# Altium schematic sheet Module.SchDoc
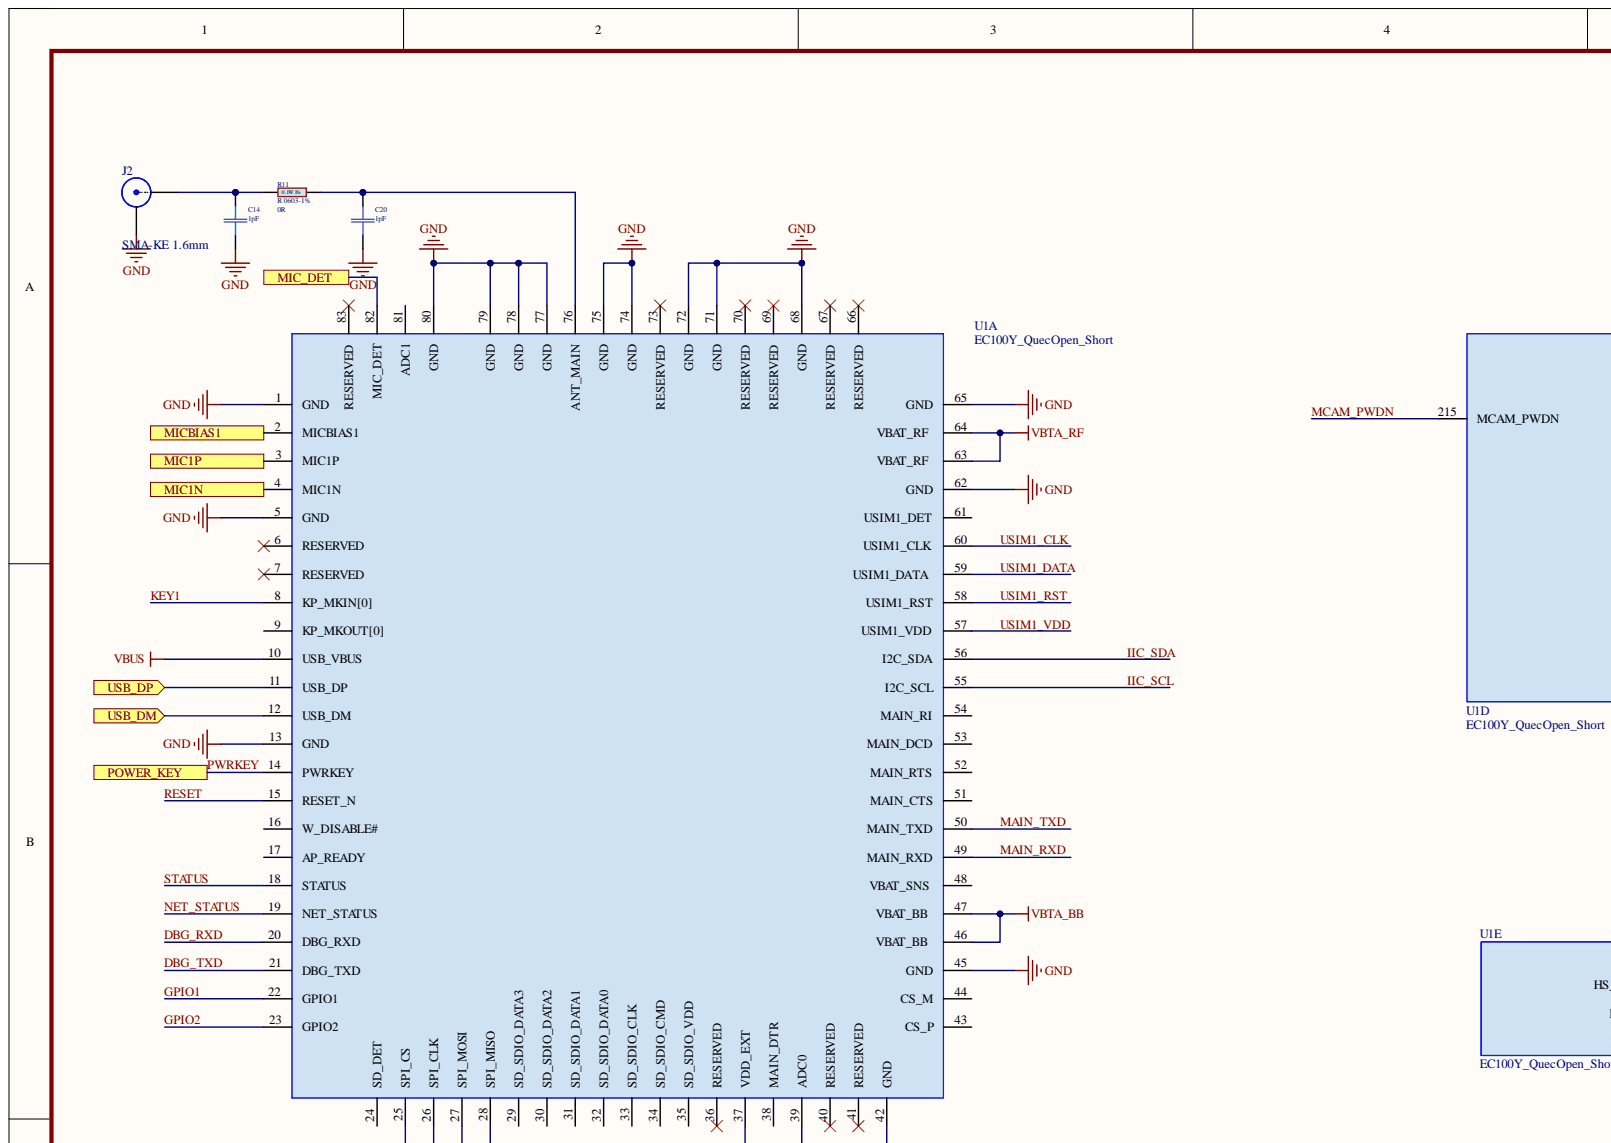
[diagram p0: Module.SchDoc, top left]
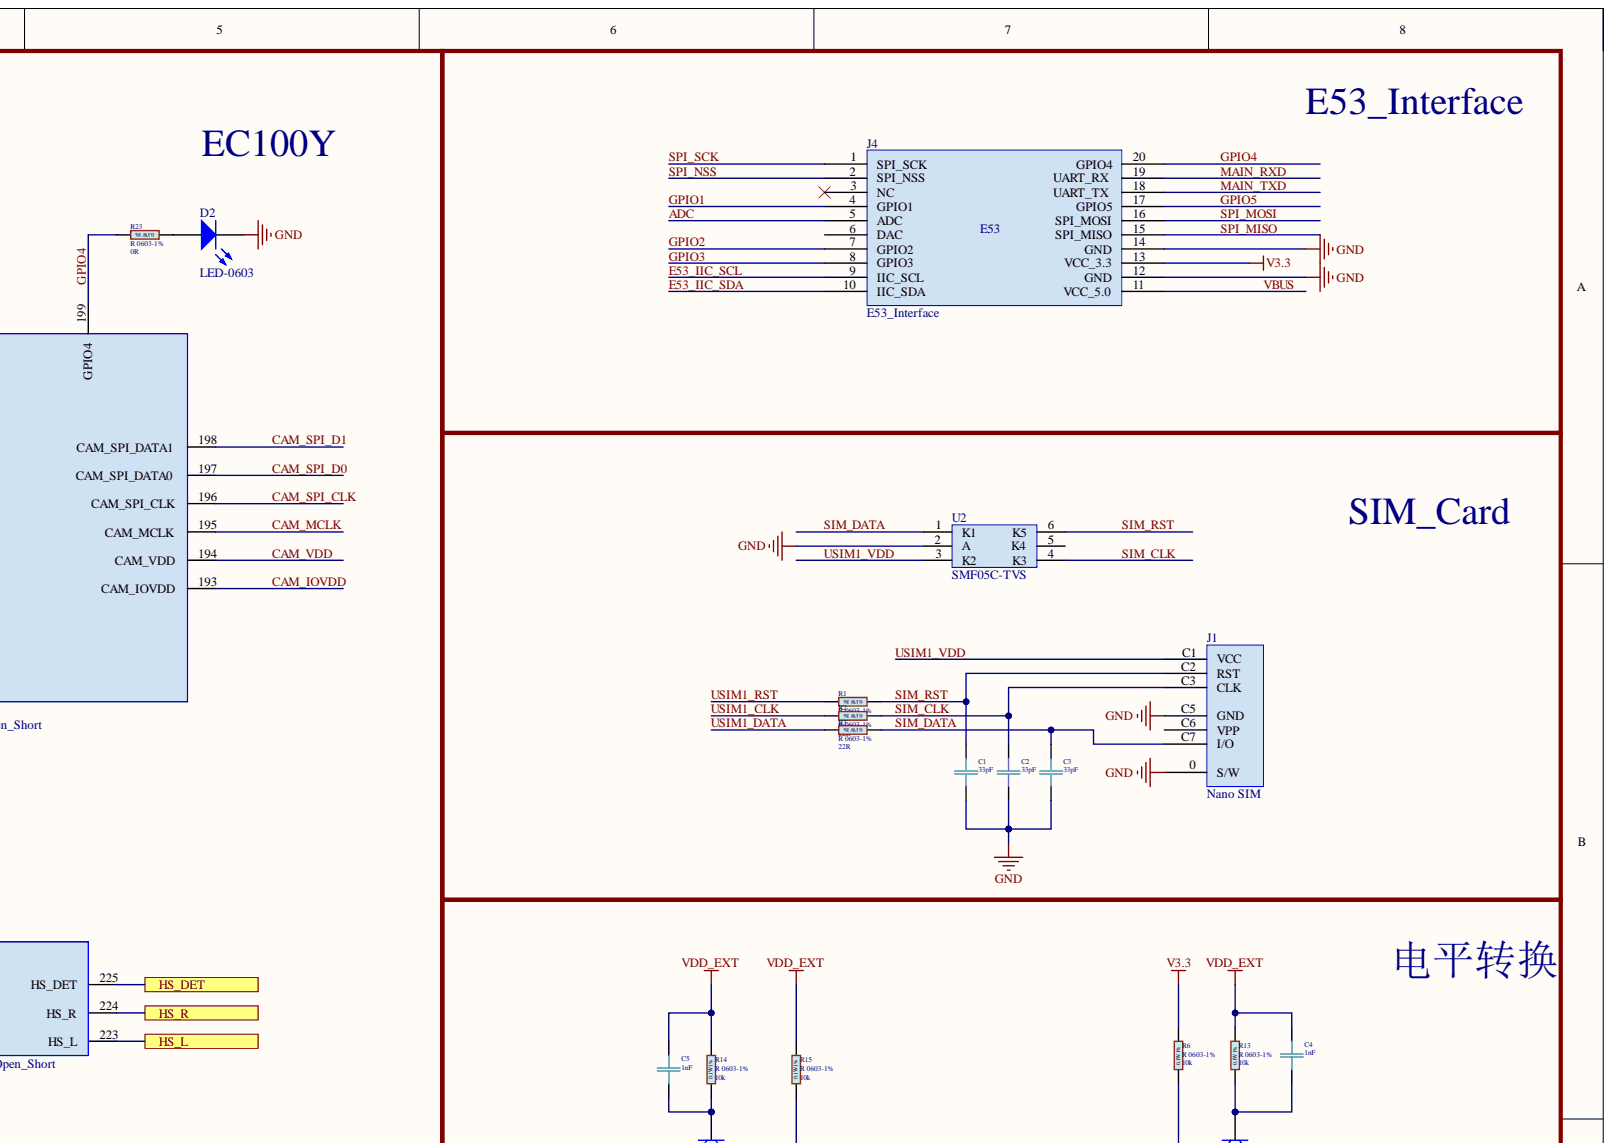
[diagram p1: Module.SchDoc, top right]
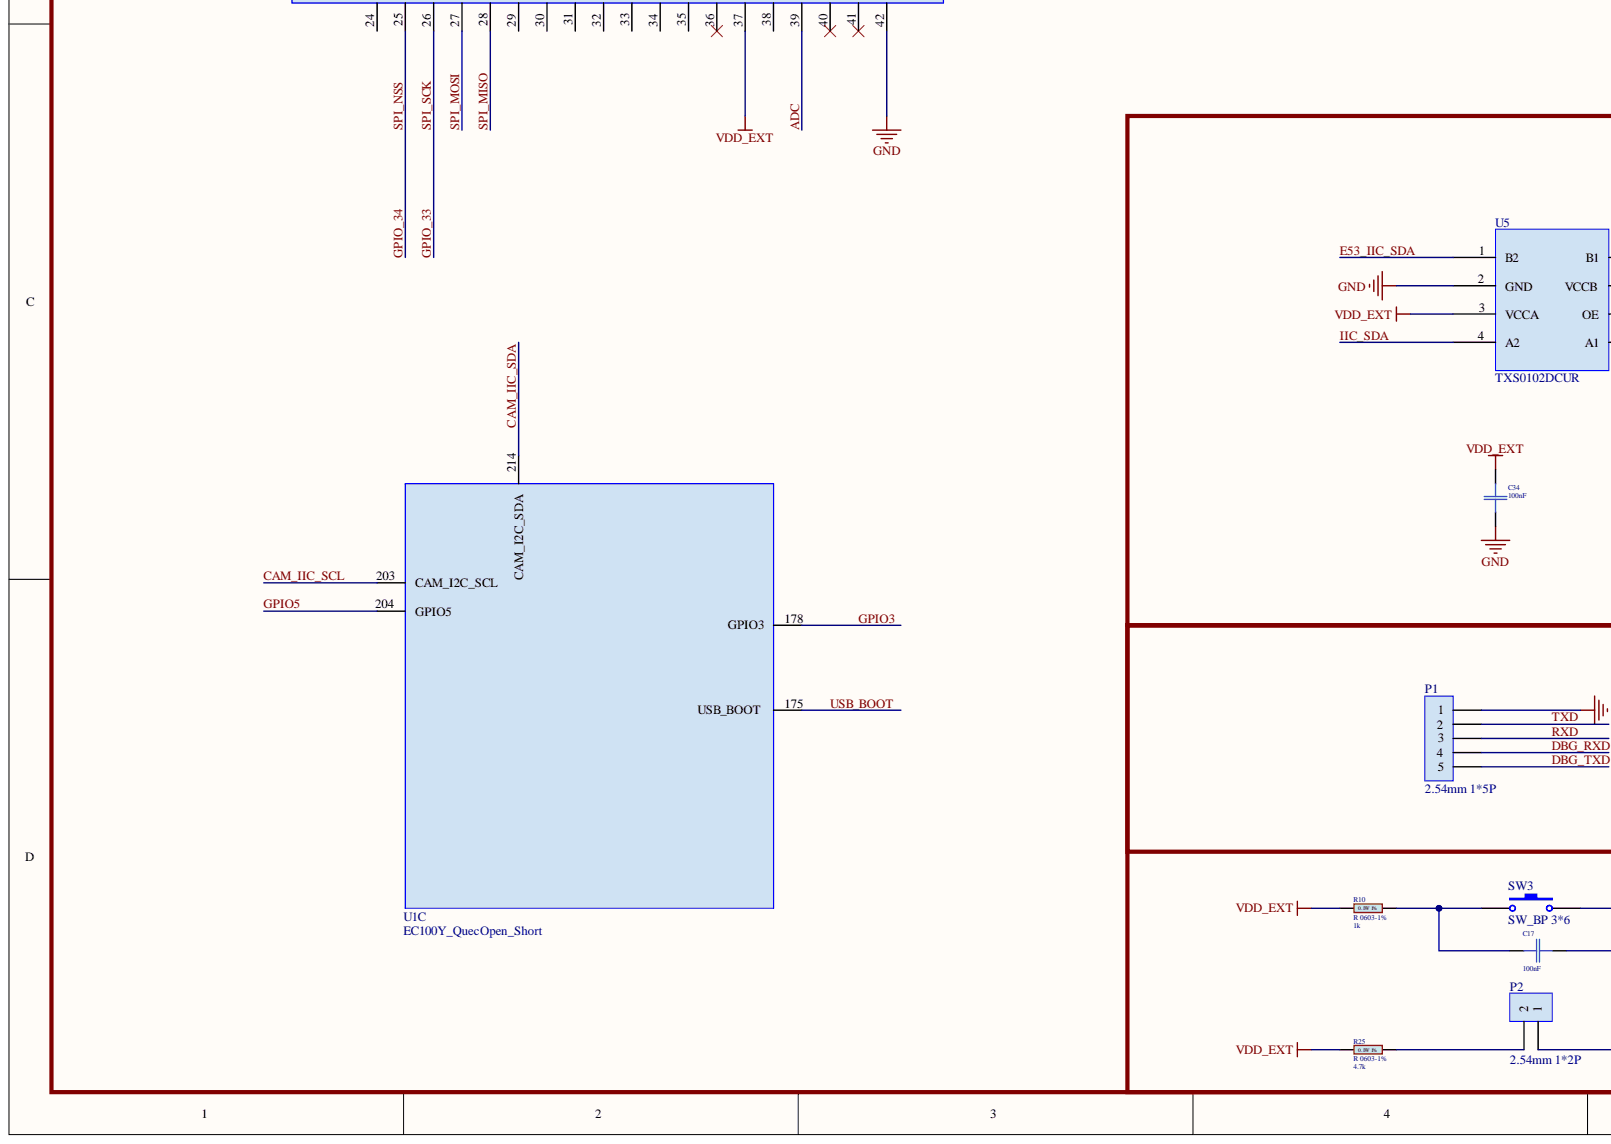
[diagram p2: Module.SchDoc, bottom left]
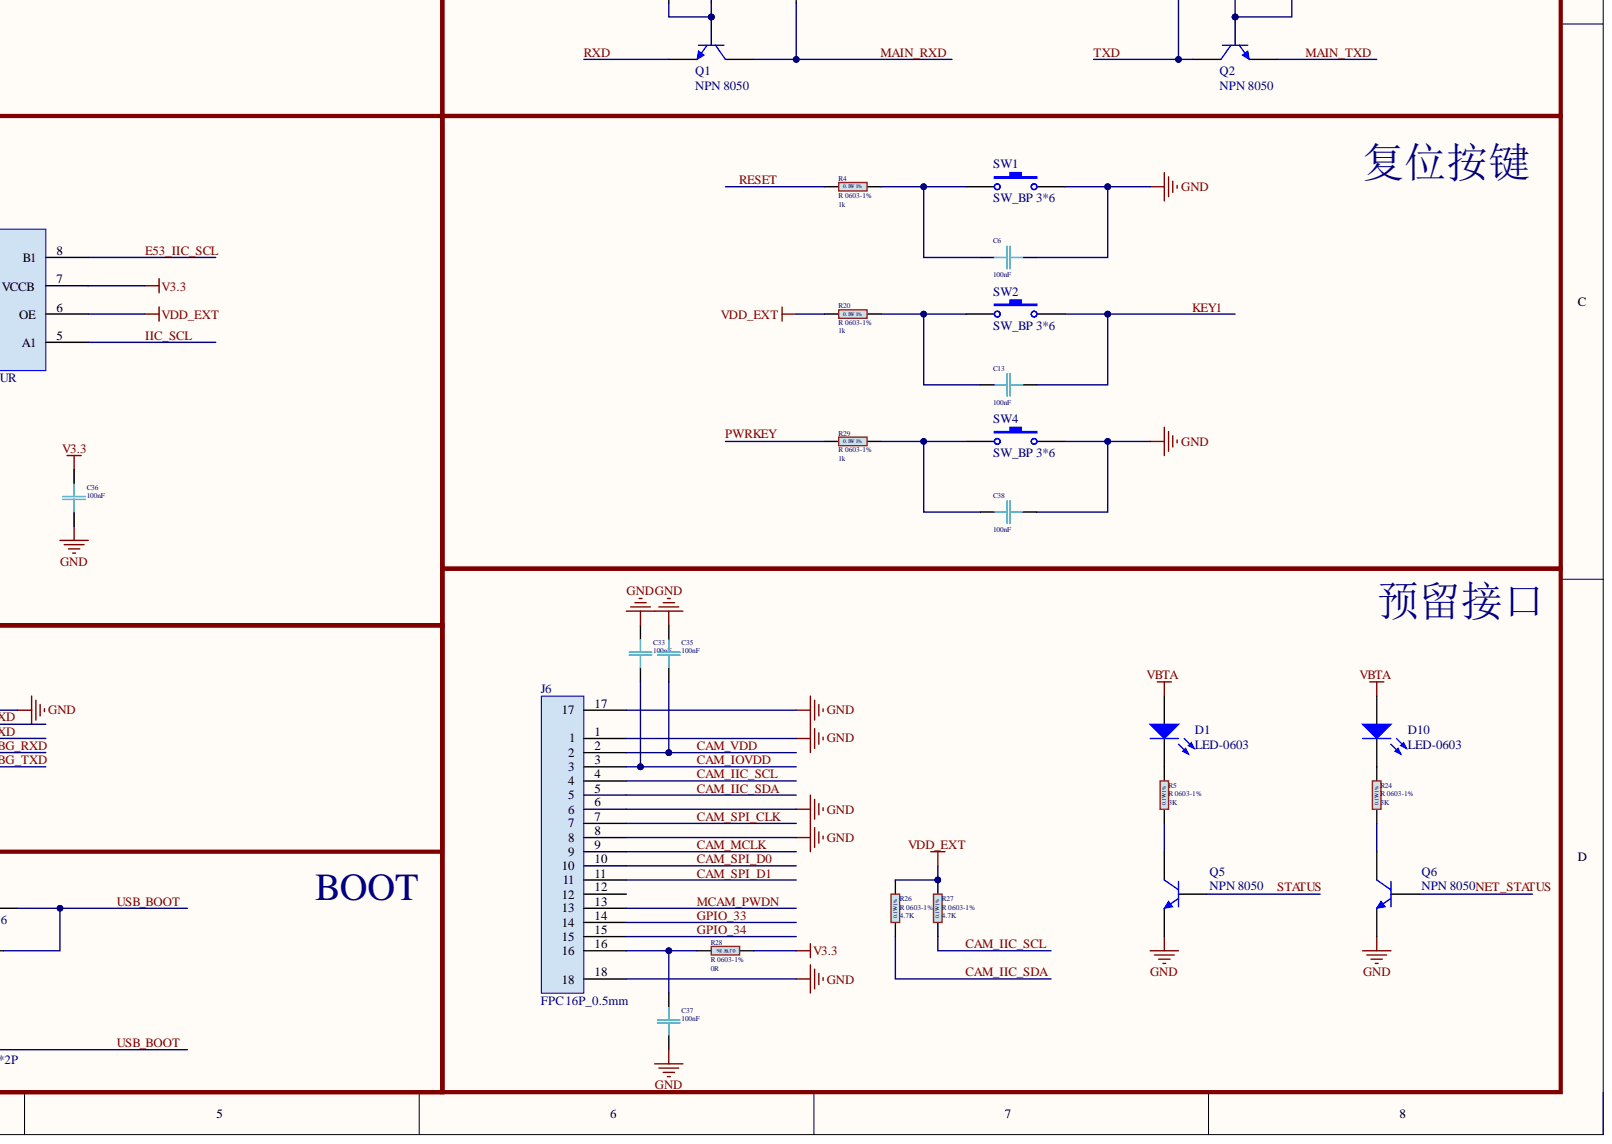
[diagram p3: Module.SchDoc, bottom right]

## Parts
C1  value 33pF  [C 0603(1608)x0.8]  (hidden comment: C 0603    50V X7R - 0*)
C2  value 33pF  [C 0603(1608)x0.8]  (hidden comment: C 0603    50V X7R - 0*)
C3  value 33pF  [C 0603(1608)x0.8]  (hidden comment: C 0603    50V X7R - 0*)
C4  value 1nF  [C 0603(1608)x0.8]  (hidden comment: C 0603    50V X7R - 0*)
C5  value 1nF  [C 0603(1608)x0.8]  (hidden comment: C 0603    50V X7R - 0*)
C6  value 100nF  [C 0603(1608)x0.8]  (hidden comment: C 0603    50V X7R - 0*)
C13  value 100nF  [C 0603(1608)x0.8]  (hidden comment: C 0603    50V X7R - 0*)
C14  value 1pF  [C 0603(1608)x0.8]  (hidden comment: C 0603    50V X7R - 0*)
C17  value 100nF  [C 0603(1608)x0.8]  (hidden comment: C 0603    50V X7R - 0*)
C20  value 1pF  [C 0603(1608)x0.8]  (hidden comment: C 0603    50V X7R - 0*)
C33  value 100nF  [C 0603(1608)x0.8]  (hidden comment: C 0603    50V X7R - 0*)
C34  value 100nF  [C 0603(1608)x0.8]  (hidden comment: C 0603    50V X7R - 0*)
C35  value 100nF  [C 0603(1608)x0.8]  (hidden comment: C 0603    50V X7R - 0*)
C36  value 100nF  [C 0603(1608)x0.8]  (hidden comment: C 0603    50V X7R - 0*)
C37  value 100nF  [C 0603(1608)x0.8]  (hidden comment: C 0603    50V X7R - 0*)
C38  value 100nF  [C 0603(1608)x0.8]  (hidden comment: C 0603    50V X7R - 0*)
D1  LED-0603  [LED-0603-Footprint-1]
D2  LED-0603  [LED-0603-Footprint-1]
D10  LED-0603  [LED-0603-Footprint-1]
J1  Nano SIM  [Nano SIM-Footprint-1]
J2  SMA-KE 1.6mm  [SMA-Footprint-1]
J4  E53_Interface  [E53_Interface-Footprint-1]
J6  FPC 16P_0.5mm  [FPC 16P_0.5mm-Footprint-1]
P1  2.54mm 1*5P  [2.54mm 1*5P-Footprint-2]
P2  2.54mm 1*2P  [2.54mm 1*2P-Footprint-2]
Q1  NPN 8050  [NPN 8050-Footprint-1]
Q2  NPN 8050  [NPN 8050-Footprint-1]
Q5  NPN 8050  [NPN 8050-Footprint-1]
Q6  NPN 8050  [NPN 8050-Footprint-1]
R1  R 0603-1%  value 22R  Tolerance: 1%  [R 0603(1608) -1%]
R2  R 0603-1%  value 22R  Tolerance: 1%  [R 0603(1608) -1%]
R3  R 0603-1%  value 22R  Tolerance: 1%  [R 0603(1608) -1%]
R4  R 0603-1%  value 1k  Tolerance: 1%  [R 0603(1608) -1%]
R5  R 0603-1%  value 3K  Tolerance: 1%  [R 0603(1608) -1%]
R6  R 0603-1%  value 10k  Tolerance: 1%  [R 0603(1608) -1%]
R10  R 0603-1%  value 1k  Tolerance: 1%  [R 0603(1608) -1%]
R11  R 0603-1%  value 0R  Tolerance: 1%  [R 0603(1608) -1%]
R13  R 0603-1%  value 10k  Tolerance: 1%  [R 0603(1608) -1%]
R14  R 0603-1%  value 10k  Tolerance: 1%  [R 0603(1608) -1%]
R15  R 0603-1%  value 10k  Tolerance: 1%  [R 0603(1608) -1%]
R20  R 0603-1%  value 1k  Tolerance: 1%  [R 0603(1608) -1%]
R23  R 0603-1%  value 0R  Tolerance: 1%  [R 0603(1608) -1%]
R24  R 0603-1%  value 3K  Tolerance: 1%  [R 0603(1608) -1%]
R25  R 0603-1%  value 4.7k  Tolerance: 1%  [R 0603(1608) -1%]
R26  R 0603-1%  value 4.7K  Tolerance: 1%  [R 0603(1608) -1%]
R27  R 0603-1%  value 4.7K  Tolerance: 1%  [R 0603(1608) -1%]
R28  R 0603-1%  value 0R  Tolerance: 1%  [R 0603(1608) -1%]
R29  R 0603-1%  value 1k  Tolerance: 1%  [R 0603(1608) -1%]
SW1  SW_BP 3*6  [SW_BP 3*6-Footprint-1]
SW2  SW_BP 3*6  [SW_BP 3*6-Footprint-1]
SW3  SW_BP 3*6  [SW_BP 3*6-Footprint-1]
SW4  SW_BP 3*6  [SW_BP 3*6-Footprint-1]
U1  EC100Y_QuecOpen_Short  [EC100Y_QuecOpen_Short-Footprint-1]  (parts A,C,D,E)
U2  SMF05C-TVS  [SMF05C-TVS-Footprint-1]
U5  TXS0102DCUR  [TXS0102DCUR-Footprint-1]

## Ports
HS_DET, HS_L, HS_R, MIC1N, MIC1P, MIC_DET, MICBIAS1, POWER_KEY, USB_DM, USB_DP

## Nets
GND: C1.1 C2.1 C3.1 C6.2 C14.1 C20.1 C33.2 C34.1 C35.2 C36.1 C37.1 C38.2 D2.2 J1.0(S/W) J1.C5(GND) J2.2 J4.12(GND) J4.14(GND) J6.1 J6.6 J6.8 J6.17 J6.18 P1.1 Q5.2 Q6.2 SW1.2 SW4.2 U1.1(GND) U1.5(GND) U1.13(GND) U1.42(GND) U1.45(GND) U1.62(GND) U1.65(GND) U1.68(GND) U1.71(GND) U1.72(GND) U1.74(GND) U1.75(GND) U1.77(GND) U1.78(GND) U1.79(GND) U1.80(GND) U2.2(A) U5.2(GND)
V3.3: C36.2 J4.13(VCC_3.3) R6.2 R28.1 U5.7(VCCB)
VBTA: D1.1 D10.1
VBTA_BB: U1.46(VBAT_BB) U1.47(VBAT_BB)
VBTA_RF: U1.63(VBAT_RF) U1.64(VBAT_RF)
VDD_EXT: C4.2 C5.2 C34.2 R10.1 R13.2 R14.2 R15.2 R20.1 R25.1 R26.2 R27.2 U1.37(VDD_EXT) U5.3(VCCA) U5.6(OE)
ADC: J4.5(ADC) U1.39(ADC0)
CAM_IIC_SCL: J6.4 R27.1 U1.203(CAM_I2C_SCL)
CAM_IIC_SDA: J6.5 R26.1 U1.214(CAM_I2C_SDA)
CAM_IOVDD: C33.1 J6.3 U1.193(CAM_IOVDD)
CAM_MCLK: J6.9 U1.195(CAM_MCLK)
CAM_SPI_CLK: J6.7 U1.196(CAM_SPI_CLK)
CAM_SPI_D0: J6.10 U1.197(CAM_SPI_DATA0)
CAM_SPI_D1: J6.11 U1.198(CAM_SPI_DATA1)
CAM_VDD: C35.1 J6.2 U1.194(CAM_VDD)
DBG_RXD: P1.4 U1.20(DBG_RXD)
DBG_TXD: P1.5 U1.21(DBG_TXD)
E53_IIC_SCL: J4.9(IIC_SCL) U5.8(B1)
E53_IIC_SDA: J4.10(IIC_SDA) U5.1(B2)
GPIO1: J4.4(GPIO1) U1.22(GPIO1)
GPIO2: J4.7(GPIO2) U1.23(GPIO2)
GPIO3: J4.8(GPIO3) U1.178(GPIO3)
GPIO4: J4.20(GPIO4) R23.2 U1.199(GPIO4)
GPIO5: J4.17(GPIO5) U1.204(GPIO5)
GPIO_33: J4.1(SPI_SCK) J6.14 U1.26(SPI_CLK)
GPIO_34: J4.2(SPI_NSS) J6.15 U1.25(SPI_CS)
HS_DET: U1.225(HS_DET) ; port HS_DET
HS_L: U1.223(HS_L) ; port HS_L
HS_R: U1.224(HS_R) ; port HS_R
IIC_SCL: U1.55(I2C_SCL) U5.5(A1)
IIC_SDA: U1.56(I2C_SDA) U5.4(A2)
KEY1: C13.2 SW2.2 U1.8(KP_MKIN[0])
MAIN_RXD: J4.19(UART_RX) Q1.3 R15.1 U1.49(MAIN_RXD)
MAIN_TXD: J4.18(UART_TX) Q2.2 U1.50(MAIN_TXD)
MCAM_PWDN: J6.13 U1.215(MCAM_PWDN)
MIC1N: U1.4(MIC1N) ; port MIC1N
MIC1P: U1.3(MIC1P) ; port MIC1P
MIC_DET: U1.82(MIC_DET) ; port MIC_DET
MICBIAS1: U1.2(MICBIAS1) ; port MICBIAS1
NET_STATUS: Q6.1 U1.19(NET_STATUS)
PWRKEY: R29.1 U1.14(PWRKEY) ; port POWER_KEY
RESET: R4.1 U1.15(RESET_N)
RXD: P1.3 Q1.2
SIM_CLK: C2.2 J1.C3(CLK) R2.1 U2.4(K3)
SIM_DATA: C3.2 J1.C7(I/O) R3.1 U2.1(K1)
SIM_RST: C1.2 J1.C2(RST) R1.1 U2.6(K5)
SPI_MISO: J4.15(SPI_MISO) U1.28(SPI_MISO)
SPI_MOSI: J4.16(SPI_MOSI) U1.27(SPI_MOSI)
STATUS: Q5.1 U1.18(STATUS)
TXD: P1.2 Q2.3 R6.1
USB_BOOT: C17.2 P2.1 SW3.2 U1.175(USB_BOOT)
USB_DM: U1.12(USB_DM) ; port USB_DM
USB_DP: U1.11(USB_DP) ; port USB_DP
USIM1_CLK: R2.2 U1.60(USIM1_CLK)
USIM1_DATA: R3.2 U1.59(USIM1_DATA)
USIM1_RST: R1.2 U1.58(USIM1_RST)
USIM1_VDD: J1.C1(VCC) U1.57(USIM1_VDD) U2.3(K2)
VBUS: J4.11(VCC_5.0) U1.10(USB_VBUS)
NetC4_1: C4.1 Q2.1 R13.1
NetC5_1: C5.1 Q1.1 R14.1
NetC6_1: C6.1 R4.2 SW1.1
NetC13_1: C13.1 R20.2 SW2.1
NetC14_2: C14.2 J2.1 R11.1
NetC17_1: C17.1 R10.2 SW3.1
NetC20_2: C20.2 R11.2 U1.76(ANT_MAIN)
NetC37_2: C37.2 J6.16 R28.2
NetC38_1: C38.1 R29.2 SW4.1
NetD1_2: D1.2 R5.2
NetD2_1: D2.1 R23.1
NetD10_2: D10.2 R24.2
NetP2_2: P2.2 R25.2
NetQ5_3: Q5.3 R5.1
NetQ6_3: Q6.3 R24.1

Not connected: J1 C6(VPP); J4 3(NC) 6(DAC); J6 12; U1 6(RESERVED) 7(RESERVED) 9(KP_MKOUT[0]) 16(W_DISABLE#) 17(AP_READY) 24(SD_DET) 29(SD_SDIO_DATA3) 30(SD_SDIO_DATA2) 31(SD_SDIO_DATA1) 32(SD_SDIO_DATA0) 33(SD_SDIO_CLK) 34(SD_SDIO_CMD) 35(SD_SDIO_VDD) 36(RESERVED) 38(MAIN_DTR) 40(RESERVED) 41(RESERVED) 43(CS_P) 44(CS_M) 48(VBAT_SNS) 51(MAIN_CTS) 52(MAIN_RTS) 53(MAIN_DCD) 54(MAIN_RI) 61(USIM1_DET) 66(RESERVED) 67(RESERVED) 69(RESERVED) 70(RESERVED) 73(RESERVED) 81(ADC1) 83(RESERVED); U2 5(K4)

## Library data of the parts
C1-C6 C13 C14 C17 C20 C33-C38 = CMP-023-0039-1: SMD 0603     50V X7R 10%; Manufacturer: Samsung; Package-mm: 1608; Case/Package: 0603; Component Kind: Standard; ComponentLink1Description: Datasheet Link; ComponentLink1URL: https://store.comet.bg/download-file.php?id=11; Design Item ID: C 0603    50V X7R - 0*; Library Name: <Company> Vault; Mount: SMT; Mount Type: SMT; Published: 07.04.2019; RoHS: Y; Signal Integrity: Capacitor; Simulation: Capacitor; Source: SMD Passive.SchLib; Technology: Ceramic  MLCC; TempCo: X7R; Temperature Range: -55°C ~ +125°C; Tolerance: 10%; VDC: 50V; Publisher: Hefest
D1 D2 D10 = CMP-00011-1: 发光二极管，520~525nm，IF20mA; Power: 5V 60mA; Component Kind: Standard; Library Name: <Company> Vault
J1 = CMP-00146-1: 掀盖式Nano SIM卡座连接器; Component Kind: Standard; Library Name: <Company> Vault
J2 = CMP-00014-6: 1.6mm 偏角贴片RF同轴连接器; frequency: 3GHz; Impedance: 50R; Component Kind: Standard; Library Name: <Company> Vault
J4 = CMP-00126-4: E53; Component Kind: Standard; Library Name: <Company> Vault
J6 = CMP-00165-2: Component Kind: Standard; Description: FPC 16P_0.5mm; Library Name: <Company> Vault
P1 = CMP-00030-3: 单排直插排针 5P; Component Kind: Standard; Library Name: <Company> Vault
P2 = CMP-00026-3: 单排直插排针 2P; Component Kind: Standard; Library Name: <Company> Vault
Q1 Q2 Q5 Q6 = CMP-00009-2: NPN Bipolar Transistor; Component Kind: Standard; Library Name: <Company> Vault
R1 = CMP-025-0004-1: SMD 0603 0.1W     1%   75V; Manufacturer: YAGEO; Package-mm: 1608; Power: 100mW; TempCo: ±100ppm/°C; Value: 22R; Value Code: 1001; Case/Package: 0603; Component Kind: Standard; ComponentLink1Description: Datasheet Link; ComponentLink1URL: https://store.comet.bg/download-file.php?id=1224; Design Item ID: R 0603-1%; Library Name: <Company> Vault; Mount: SMT; Mount Type: SMT; Price: 0.017; Published: 07.04.2019; RoHS: Y; Signal Integrity: Resistor; Simulation: Resistor; Source: SMD Passive.SchLib; Technology: Thick film; Temperature Range: -55°C ~ +155°C; VDC: 75V; Publisher: Hefest
R2-R6 R10 R11 R13-R15 R20 R23-R29 = CMP-025-0004-1: SMD 0603 0.1W     1%   75V; Manufacturer: YAGEO; Package-mm: 1608; Power: 100mW; TempCo: ±100ppm/°C; Value Code: 1001; Case/Package: 0603; Component Kind: Standard; ComponentLink1Description: Datasheet Link; ComponentLink1URL: https://store.comet.bg/download-file.php?id=1224; Design Item ID: R 0603-1%; Library Name: <Company> Vault; Mount: SMT; Mount Type: SMT; Price: 0.017; Published: 07.04.2019; RoHS: Y; Signal Integrity: Resistor; Simulation: Resistor; Source: SMD Passive.SchLib; Technology: Thick film; Temperature Range: -55°C ~ +155°C; VDC: 75V; Publisher: Hefest
SW1-SW4 = CMP-00049-1: 3×6贴片轻触开关; current: 50mA @ 12VDC; Component Kind: Standard; Library Name: <Company> Vault
U1 = CMP-00131-11: Component Kind: Standard; Description: EC100Y_QuecOpen_Short; Library Name: <Company> Vault
U2 = CMP-00068-1: TVS齐纳二极管; 电压 - 反向关态: 5V; Component Kind: Standard; Library Name: <Company> Vault
U5 = CMP-00121-1: TEXAS INSTRUMENTS   TXS0102DCUR   IC, TRANSLATOR, FULL REEL; Data Rate: 24Mbps; High Level Output Current: -20uA; Low Level Output Current: 1mA; Max Supply Voltage: 5.5V; Min Supply Voltage: 1.65V; Nominal Supply Current: 14.4uA; Number of Bits: 2; Output Current: 1A; Output Type: Voltage; Propagation Delay: 4.6ns; Turn-On Delay Time: 260ns; Case/Package: VSSOP; Component Kind: Standard; Lead Free: Lead Free; Library Name: <Company> Vault; Logic Function: Translator; Max Operating Temperature: 85°C; Min Operating Temperature: -40°C; Number of Channels: 2; Number of Inputs: 2; Number of Pins: 8; Package Quantity: 1; Packaging: Tape and Reel; Quiescent Current: 14.4uA; Radiation Hardening: No; REACH SVHC: No SVHC; Weight: 0.000339oz
